# Altium schematic sheet CAN Controllers.SchDoc
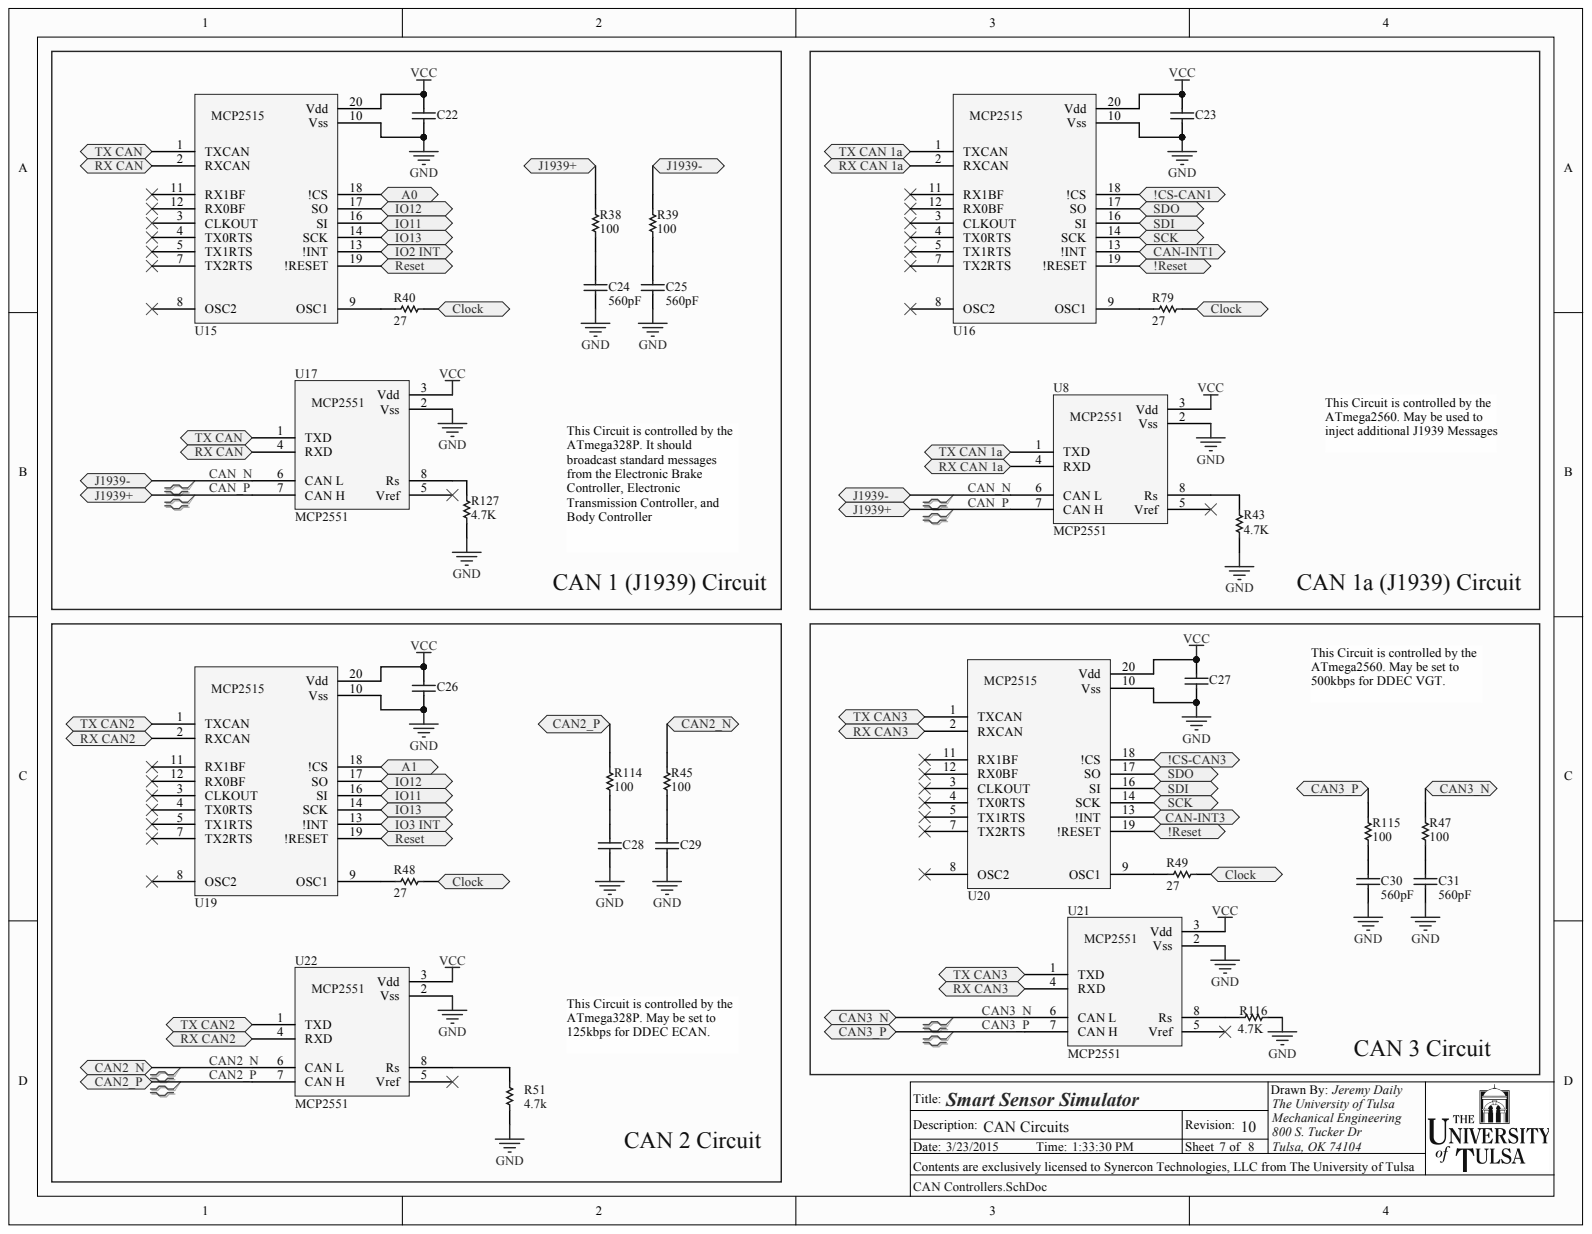
[diagram p0: CAN Controllers.SchDoc]

## Parts
C22  0.1uF  [0603]  (value not drawn)
C23  0.1uF  [0603]  (value not drawn)
C24  560pF  [0603]
C25  560pF  [0603]
C26  0.1uF  [0603]  (value not drawn)
C27  0.1uF  [0603]  (value not drawn)
C28  560pF  [0603]  (value not drawn)
C29  560pF  [0603]  (value not drawn)
C30  560pF  [0603]
C31  560pF  [0603]
R38  100  [0805]
R39  100  [0805]
R40  27  [0805]
R43  4.7K  [0805]
R45  100  [0805]
R47  100  [0805]
R48  27  [0805]
R49  27  [0805]
R51  4.7k  [0805]
R79  27  [0805]
R114  100  [0805]
R115  100  [0805]
R116  4.7K  [0805]
R127  4.7K  [0805]
U8  MCP2551  [SOIC-8]
U15  MCP2515  [TI-TSSOP20]
U16  MCP2515  [TI-TSSOP20]
U17  MCP2551  [SOIC-8]
U19  MCP2515  [TI-TSSOP20]
U20  MCP2515  [TI-TSSOP20]
U21  MCP2551  [SOIC-8]
U22  MCP2551  [SOIC-8]

## Ports
!CS-CAN1, !CS-CAN3, !RESET, A0, A1, CAN2_N, CAN2_P, CAN3_N, CAN3_P, CAN-INT1, CAN-INT3, CLOCK, IO2 INT, IO3 INT, IO11, IO12, IO13, J1939+, J1939-, RESET, RX CAN, RX CAN2, RX CAN3, RX CAN 1A, SCK, SDI, SDO, TX CAN, TX CAN2, TX CAN3, TX CAN 1A

## Nets
GND: C22.1 C23.1 C24.1 C25.1 C26.1 C27.1 C28.1 C29.1 C30.1 C31.1 R43.2 R51.2 R116.2 R127.2 U8.2(Vss) U15.10(Vss) U16.10(Vss) U17.2(Vss) U19.10(Vss) U20.10(Vss) U21.2(Vss) U22.2(Vss)
VCC: C22.2 C23.2 C26.2 C27.2 U8.3(Vdd) U15.20(Vdd) U16.20(Vdd) U17.3(Vdd) U19.20(Vdd) U20.20(Vdd) U21.3(Vdd) U22.3(Vdd)
!CS-CAN1: U16.18(!CS) ; port !CS-CAN1
!CS-CAN3: U20.18(!CS) ; port !CS-CAN3
A0: U15.18(!CS) ; port A0
A1: U19.18(!CS) ; port A1
CAN2_N: R45.1 U22.6(CAN_L) ; port CAN2_N
CAN2_P: R114.1 U22.7(CAN_H) ; port CAN2_P
CAN3_N: R47.1 U21.6(CAN_L) ; port CAN3_N
CAN3_P: R115.1 U21.7(CAN_H) ; port CAN3_P
CAN-INT1: U16.13(!INT) ; port CAN-INT1
CAN-INT3: U20.13(!INT) ; port CAN-INT3
CAN_N: R39.1 U8.6(CAN_L) U17.6(CAN_L)
CAN_P: R38.1 U8.7(CAN_H) U17.7(CAN_H)
IO2 INT: U15.13(!INT) ; port IO2 INT
IO3 INT: U19.13(!INT) ; port IO3 INT
IO11: U15.16(SI) U19.16(SI) ; port IO11
IO12: U15.17(SO) U19.17(SO) ; port IO12
IO13: U15.14(SCK) U19.14(SCK) ; port IO13
RX CAN: U15.2(RXCAN) U17.4(RXD) ; port RX CAN
RX CAN2: U19.2(RXCAN) U22.4(RXD) ; port RX CAN2
RX CAN3: U20.2(RXCAN) U21.4(RXD) ; port RX CAN3
SCK: U16.14(SCK) U20.14(SCK) ; port SCK
SDI: U16.16(SI) U20.16(SI) ; port SDI
SDO: U16.17(SO) U20.17(SO) ; port SDO
TX CAN: U15.1(TXCAN) U17.1(TXD) ; port TX CAN
TX CAN2: U19.1(TXCAN) U22.1(TXD) ; port TX CAN2
TX CAN3: U20.1(TXCAN) U21.1(TXD) ; port TX CAN3
NetC24_2: C24.2 R38.2
NetC25_2: C25.2 R39.2
NetC28_2: C28.2 R114.2
NetC29_2: C29.2 R45.2
NetC30_2: C30.2 R115.2
NetC31_2: C31.2 R47.2
NetR40_1: R40.1 U15.9(OSC1)
NetR40_2: R40.2 R48.2 R49.2 R79.2 ; port CLOCK
NetR43_1: R43.1 U8.8(Rs)
NetR48_1: R48.1 U19.9(OSC1)
NetR49_1: R49.1 U20.9(OSC1)
NetR51_1: R51.1 U22.8(Rs)
NetR79_1: R79.1 U16.9(OSC1)
NetR116_1: R116.1 U21.8(Rs)
NetR127_1: R127.1 U17.8(Rs)
NetU8_1: U8.1(TXD) U16.1(TXCAN) ; port TX CAN 1A
NetU8_4: U8.4(RXD) U16.2(RXCAN) ; port RX CAN 1A
NetU15_19: U15.19(!RESET) U19.19(!RESET) ; port RESET
NetU16_19: U16.19(!RESET) U20.19(!RESET) ; port !RESET

Not connected: U8 5(Vref); U15 3(CLKOUT) 4(TX0RTS) 5(TX1RTS) 7(TX2RTS) 8(OSC2) 11(RX1BF) 12(RX0BF); U16 3(CLKOUT) 4(TX0RTS) 5(TX1RTS) 7(TX2RTS) 8(OSC2) 11(RX1BF) 12(RX0BF); U17 5(Vref); U19 3(CLKOUT) 4(TX0RTS) 5(TX1RTS) 7(TX2RTS) 8(OSC2) 11(RX1BF) 12(RX0BF); U20 3(CLKOUT) 4(TX0RTS) 5(TX1RTS) 7(TX2RTS) 8(OSC2) 11(RX1BF) 12(RX0BF); U21 5(Vref); U22 5(Vref)

## Library data of the parts
C22 C23 C26 C27 = Cap: CAP CER 0.1UF 50V 10% X7R 0603; Manufacturer: Murata Electronics North America; PartNumber: GRM188R71H104KA93D; Component Kind: Standard; Library Name: SSS Schematic Library.SchLib; Signal Integrity: Cap; Simulation: CAP; Supplier 1: Digi-Key; Supplier Part Number 1: 490-1519-1-ND
C24 C25 C28-C31 = Cap: CAP CER 560PF 50V 5% NP0 0603; Manufacturer: Kemet; PartNumber: C0603C561J5GACTU; Component Kind: Standard; Library Name: SSS Schematic Library.SchLib; Signal Integrity: Cap; Simulation: CAP; Supplier 1: Digi-Key; Supplier Part Number 1: 399-3287-1-ND
R38 R39 R45 R47 R114 R115 = Chip Resistor: RES SMD 100 OHM 1% 1/2W 0805; Manufacturer: Panasonic Electronic Components; PartNumber: ERJ-P6WF1000V; Component Kind: Standard; Library Name: SSS Schematic Library.SchLib; Supplier 1: Digi-Key; Supplier Part Number 1: P16873CT-ND
R40 R48 R49 R79 = Chip Resistor: RES SMD 27 OHM 1% 1/8W 0805; Manufacturer: Panasonic Electronic Components; PartNumber: ERJ-6ENF27R0V; Component Kind: Standard; Library Name: SSS Schematic Library.SchLib; Supplier 1: Digi-Key; Supplier Part Number 1: P27.0CCT-ND
R43 R51 R116 R127 = Chip Resistor: RES SMD 4.7K OHM 1% 1/8W 0805; Manufacturer: Panasonic Electronic Components; PartNumber: ERJ-6ENF4701V; Component Kind: Standard; Library Name: SSS Schematic Library.SchLib; Supplier 1: Digi-Key; Supplier Part Number 1: P4.70KCCT-ND
U8 U17 U21 U22 = MCP2551: IC TXRX CAN 8SOIC; Manufacturer: Microchip; PartNumber: MCP2561-E/SN; Component Kind: Standard; Library Name: SSS Schematic Library.SchLib; Supplier 1: Digi-Key; Supplier Part Number 1: MCP2561-E/SN-ND
U15 U16 U19 U20 = MCP2515 (TSSOP): IC CAN CONTROLLER W/SPI 20TSSOP; Manufacturer: Microchip; PartNumber: MCP2515T-I/ST; Component Kind: Standard; Supplier 1: Digi-Key; Supplier Part Number 1: MCP2515T-I/STCT-ND; Library Name: Communications.SchLib
